# Altium schematic sheet SD_Card_Led_Monitor.SchDoc
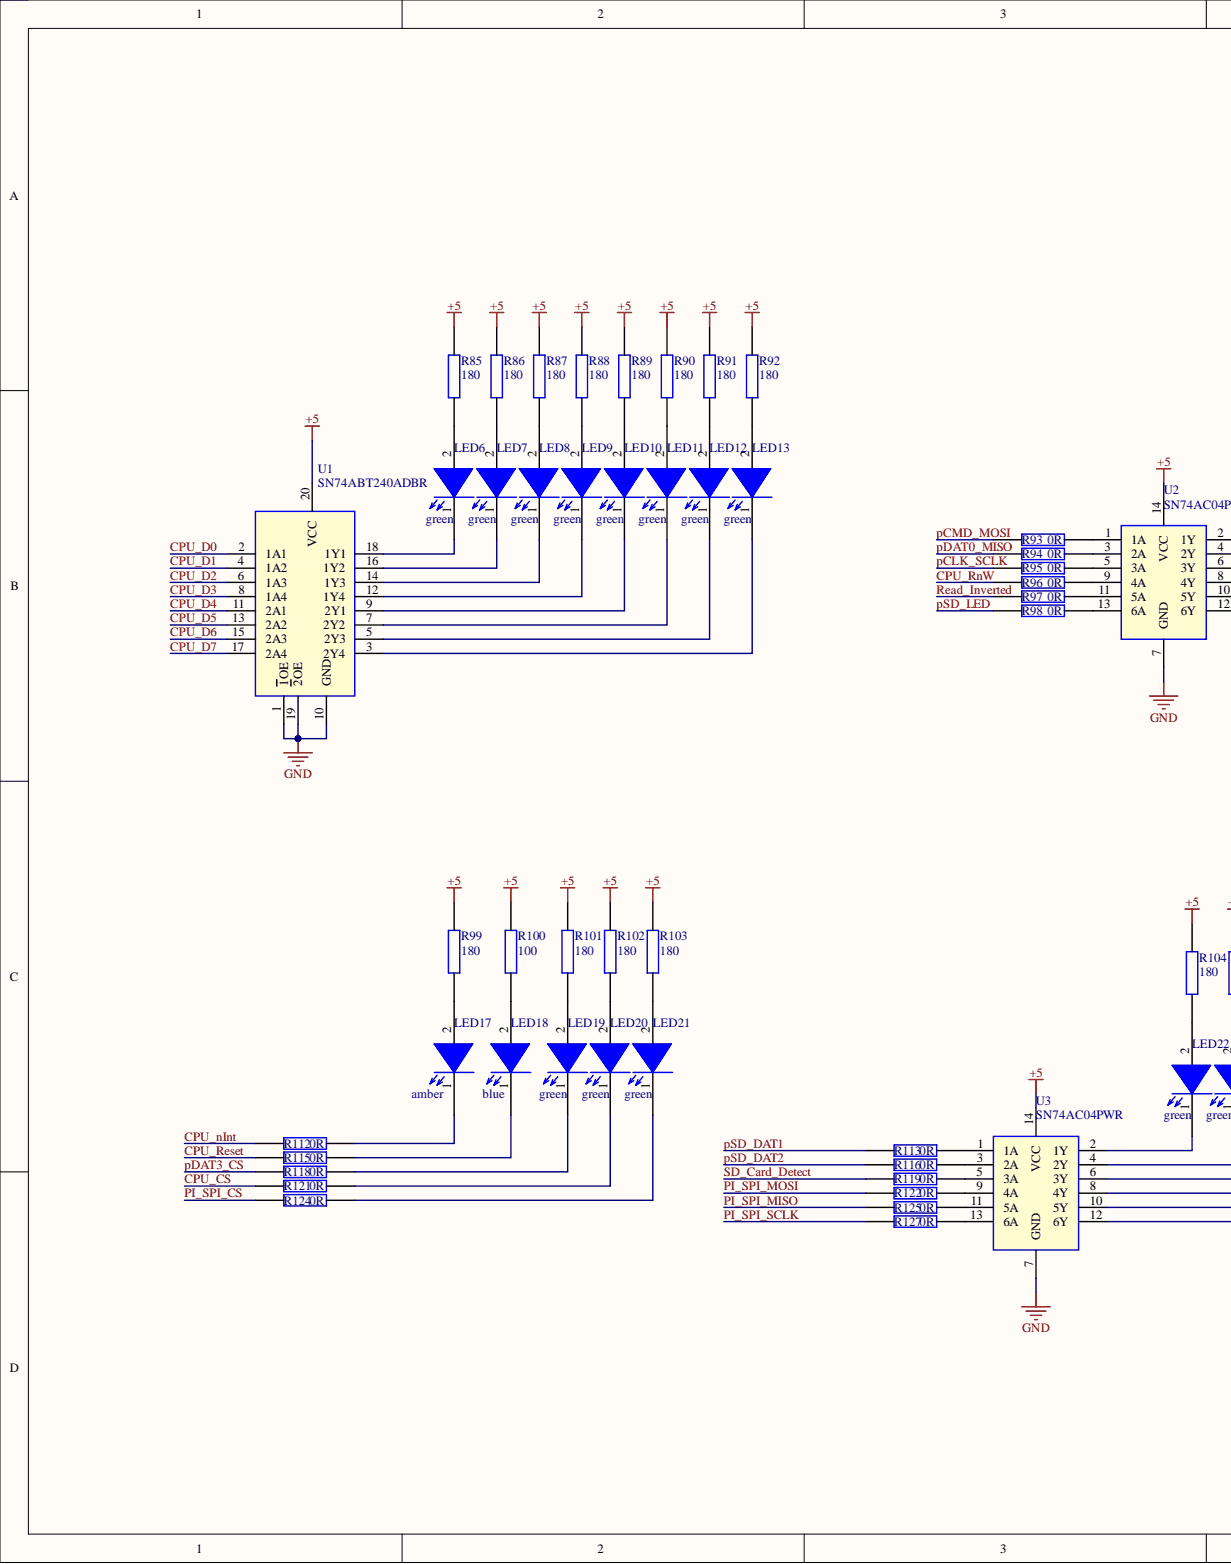
[diagram p0: SD_Card_Led_Monitor.SchDoc, left]
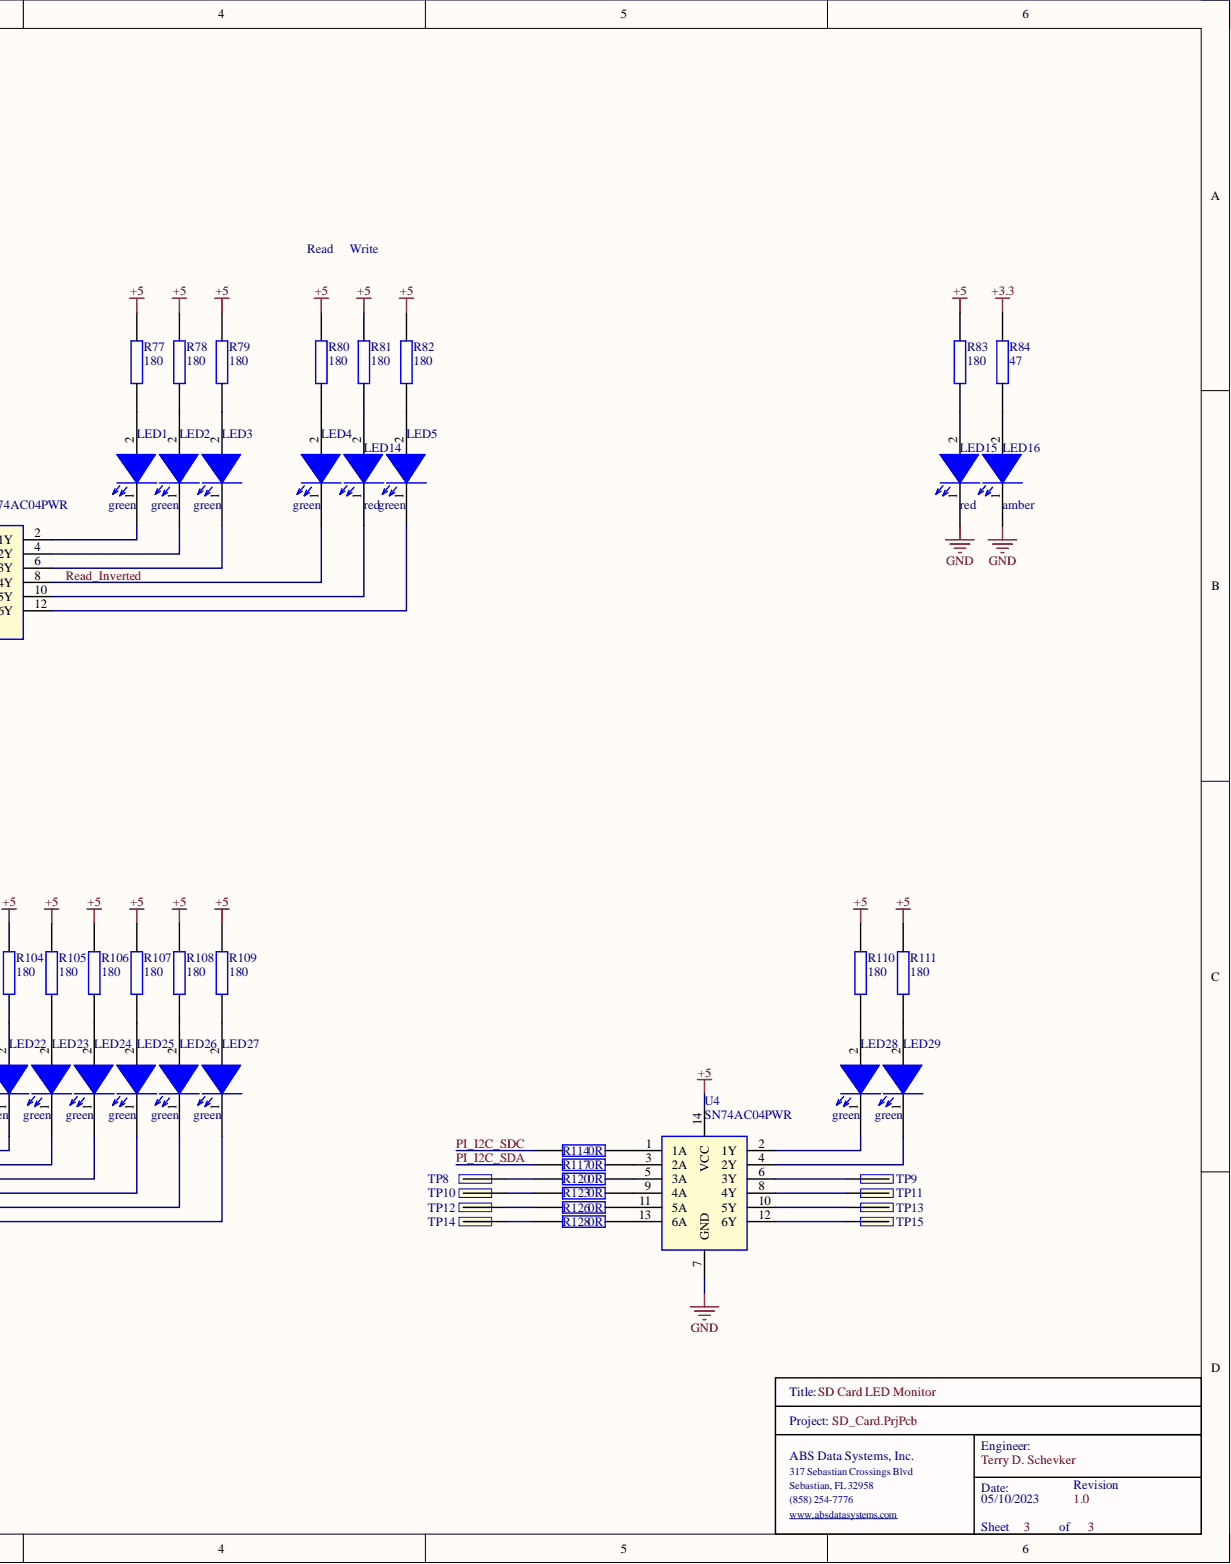
[diagram p1: SD_Card_Led_Monitor.SchDoc, right]

## Parts
LED1  green  [0603_Diode]
LED2  green  [0603_Diode]
LED3  green  [0603_Diode]
LED4  green  [0603_Diode]
LED5  green  [0603_Diode]
LED6  green  [0603_Diode]
LED7  green  [0603_Diode]
LED8  green  [0603_Diode]
LED9  green  [0603_Diode]
LED10  green  [0603_Diode]
LED11  green  [0603_Diode]
LED12  green  [0603_Diode]
LED13  green  [0603_Diode]
LED14  red  [0603_Diode]
LED15  red  [0603_Diode]
LED16  amber  [0603_Diode]
LED17  amber  [0603_Diode]
LED18  blue  [0603_Diode]
LED19  green  [0603_Diode]
LED20  green  [0603_Diode]
LED21  green  [0603_Diode]
LED22  green  [0603_Diode]
LED23  green  [0603_Diode]
LED24  green  [0603_Diode]
LED25  green  [0603_Diode]
LED26  green  [0603_Diode]
LED27  green  [0603_Diode]
LED28  green  [0603_Diode]
LED29  green  [0603_Diode]
R77  180  [0603]
R78  180  [0603]
R79  180  [0603]
R80  180  [0603]
R81  180  [0603]
R82  180  [0603]
R83  180  [0603]
R84  47  [0603]
R85  180  [0603]
R86  180  [0603]
R87  180  [0603]
R88  180  [0603]
R89  180  [0603]
R90  180  [0603]
R91  180  [0603]
R92  180  [0603]
R93  0R  [0603]
R94  0R  [0603]
R95  0R  [0603]
R96  0R  [0603]
R97  0R  [0603]
R98  0R  [0603]
R99  180  [0603]
R100  100  [0603]
R101  180  [0603]
R102  180  [0603]
R103  180  [0603]
R104  180  [0603]
R105  180  [0603]
R106  180  [0603]
R107  180  [0603]
R108  180  [0603]
R109  180  [0603]
R110  180  [0603]
R111  180  [0603]
R112  0R  [0603]  (value not drawn)
R113  0R  [0603]  (value not drawn)
R114  0R  [0603]  (value not drawn)
R115  0R  [0603]  (value not drawn)
R116  0R  [0603]  (value not drawn)
R117  0R  [0603]  (value not drawn)
R118  0R  [0603]  (value not drawn)
R119  0R  [0603]  (value not drawn)
R120  0R  [0603]  (value not drawn)
R121  0R  [0603]  (value not drawn)
R122  0R  [0603]  (value not drawn)
R123  0R  [0603]  (value not drawn)
R124  0R  [0603]  (value not drawn)
R125  0R  [0603]  (value not drawn)
R126  0R  [0603]  (value not drawn)
R127  0R  [0603]  (value not drawn)
R128  0R  [0603]  (value not drawn)
TP8  TP  [TP]
TP9  TP  [TP]
TP10  TP  [TP]
TP11  TP  [TP]
TP12  TP  [TP]
TP13  TP  [TP]
TP14  TP  [TP]
TP15  TP  [TP]
U1  SN74ABT240ADBR  [SOP65P780X200-20N]
U2  SN74AC04PWR  [SOP65P640X120-14N]
U3  SN74AC04PWR  [SOP65P640X120-14N]
U4  SN74AC04PWR  [SOP65P640X120-14N]

## Nets
+3.3: R84.1
+5: R77.2 R78.2 R79.2 R80.2 R81.2 R82.2 R83.2 R85.2 R86.2 R87.2 R88.2 R89.2 R90.2 R91.2 R92.2 R99.2 R100.1 R101.2 R102.2 R103.2 R104.2 R105.2 R106.2 R107.2 R108.2 R109.2 R110.2 R111.2 U1.20(VCC) U2.14(VCC) U3.14(VCC) U4.14(VCC)
GND: LED15.1 LED16.1 U1.1(1OE) U1.10(GND) U1.19(2OE) U2.7(GND) U3.7(GND) U4.7(GND)
CPU_CS: R121.1
CPU_D0: U1.2(1A1)
CPU_D1: U1.4(1A2)
CPU_D2: U1.6(1A3)
CPU_D3: U1.8(1A4)
CPU_D4: U1.11(2A1)
CPU_D5: U1.13(2A2)
CPU_D6: U1.15(2A3)
CPU_D7: U1.17(2A4)
CPU_nInt: R112.1
CPU_Reset: R115.1
CPU_RnW: R96.1
pCLK_SCLK: R95.1
pCMD_MOSI: R93.1
pDAT0_MISO: R94.1
pDAT3_CS: R118.1
PI_I2C_SDA: R117.1
PI_I2C_SDC: R114.1
PI_SPI_CS: R124.1
PI_SPI_MISO: R125.1
PI_SPI_MOSI: R122.1
PI_SPI_SCLK: R127.1
pSD_DAT1: R113.1
pSD_DAT2: R116.1
pSD_LED: R98.1
Read_Inverted: LED4.1 R97.1 U2.8(4Y)
SD_Card_Detect: R119.1
NetLED1_1: LED1.1 U2.2(1Y)
NetLED1_2: LED1.2 R77.1
NetLED2_1: LED2.1 U2.4(2Y)
NetLED2_2: LED2.2 R78.1
NetLED3_1: LED3.1 U2.6(3Y)
NetLED3_2: LED3.2 R79.1
NetLED4_2: LED4.2 R80.1
NetLED5_1: LED5.1 U2.12(6Y)
NetLED5_2: LED5.2 R82.1
NetLED6_1: LED6.1 U1.18(1Y1)
NetLED6_2: LED6.2 R85.1
NetLED7_1: LED7.1 U1.16(1Y2)
NetLED7_2: LED7.2 R86.1
NetLED8_1: LED8.1 U1.14(1Y3)
NetLED8_2: LED8.2 R87.1
NetLED9_1: LED9.1 U1.12(1Y4)
NetLED9_2: LED9.2 R88.1
NetLED10_1: LED10.1 U1.9(2Y1)
NetLED10_2: LED10.2 R89.1
NetLED11_1: LED11.1 U1.7(2Y2)
NetLED11_2: LED11.2 R90.1
NetLED12_1: LED12.1 U1.5(2Y3)
NetLED12_2: LED12.2 R91.1
NetLED13_1: LED13.1 U1.3(2Y4)
NetLED13_2: LED13.2 R92.1
NetLED14_1: LED14.1 U2.10(5Y)
NetLED14_2: LED14.2 R81.1
NetLED15_2: LED15.2 R83.1
NetLED16_2: LED16.2 R84.2
NetLED17_1: LED17.1 R112.2
NetLED17_2: LED17.2 R99.1
NetLED18_1: LED18.1 R115.2
NetLED18_2: LED18.2 R100.2
NetLED19_1: LED19.1 R118.2
NetLED19_2: LED19.2 R101.1
NetLED20_1: LED20.1 R121.2
NetLED20_2: LED20.2 R102.1
NetLED21_1: LED21.1 R124.2
NetLED21_2: LED21.2 R103.1
NetLED22_1: LED22.1 U3.2(1Y)
NetLED22_2: LED22.2 R104.1
NetLED23_1: LED23.1 U3.4(2Y)
NetLED23_2: LED23.2 R105.1
NetLED24_1: LED24.1 U3.6(3Y)
NetLED24_2: LED24.2 R106.1
NetLED25_1: LED25.1 U3.8(4Y)
NetLED25_2: LED25.2 R107.1
NetLED26_1: LED26.1 U3.10(5Y)
NetLED26_2: LED26.2 R108.1
NetLED27_1: LED27.1 U3.12(6Y)
NetLED27_2: LED27.2 R109.1
NetLED28_1: LED28.1 U4.2(1Y)
NetLED28_2: LED28.2 R110.1
NetLED29_1: LED29.1 U4.4(2Y)
NetLED29_2: LED29.2 R111.1
NetR93_2: R93.2 U2.1(1A)
NetR94_2: R94.2 U2.3(2A)
NetR95_2: R95.2 U2.5(3A)
NetR96_2: R96.2 U2.9(4A)
NetR97_2: R97.2 U2.11(5A)
NetR98_2: R98.2 U2.13(6A)
NetR113_2: R113.2 U3.1(1A)
NetR114_2: R114.2 U4.1(1A)
NetR116_2: R116.2 U3.3(2A)
NetR117_2: R117.2 U4.3(2A)
NetR119_2: R119.2 U3.5(3A)
NetR120_1: R120.1 TP8.1
NetR120_2: R120.2 U4.5(3A)
NetR122_2: R122.2 U3.9(4A)
NetR123_1: R123.1 TP10.1
NetR123_2: R123.2 U4.9(4A)
NetR125_2: R125.2 U3.11(5A)
NetR126_1: R126.1 TP12.1
NetR126_2: R126.2 U4.11(5A)
NetR127_2: R127.2 U3.13(6A)
NetR128_1: R128.1 TP14.1
NetR128_2: R128.2 U4.13(6A)
NetTP9_1: TP9.1 U4.6(3Y)
NetTP11_1: TP11.1 U4.8(4Y)
NetTP13_1: TP13.1 U4.10(5Y)
NetTP15_1: TP15.1 U4.12(6Y)

## Library data of the parts
LED1-LED13 LED19-LED29 = 150060GS75000: Wurth Elektronik 0603 green; Manufacturer: Wurth Electronics; Dominant Wavelength: 520nm; Forward Current: 30mA; Forward Voltage: 3.2V; Lens Style: Clear,Rectangular; Luminous Intensity: 430mcd; Test Current: 20mA; Viewing Angle: 140°; Case Code (Imperial): 0603; Case Code (Metric): 1608; Case/Package: 0603; Category: LEDs; Color: Green; Component Kind: Standard; ComponentLink1Description: https://datasheet.ciiva.com/29562/150060gs75000-29562868.pdf?src-supplier=Digi-Key; ComponentLink1URL: https://datasheet.ciiva.com/29562/150060gs75000-29562868.pdf?src-supplier=Digi-Key; ComponentLink2Description: https://datasheet.ciiva.com/29279/759427-da-01-en-chipled-0603-w-kl-gruen-150060gs75000-29279201.pdf?src-supplier=Conrad; ComponentLink2URL: https://datasheet.ciiva.com/29279/759427-da-01-en-chipled-0603-w-kl-gruen-150060gs75000-29279201.pdf?src-supplier=Conrad; ComponentLink3Description: https://datasheet.ciiva.com/29562/150060gs75000-29562869.pdf?src-supplier=Digi-Key; ComponentLink3URL: https://datasheet.ciiva.com/29562/150060gs75000-29562869.pdf?src-supplier=Digi-Key; ComponentLink4Description: https://datasheet.ciiva.com/29562/150060gs75000-29562870.pdf?src-supplier=Digi-Key; ComponentLink4URL: https://datasheet.ciiva.com/29562/150060gs75000-29562870.pdf?src-supplier=Digi-Key; Height: 0.7mm; Lead Free: Lead Free; Length: 1.6mm; Library Name: Misc.SchLib; Manufacturer Part Number: 150060GS75000; Max Operating Temperature: 85°C; Min Operating Temperature: -40°C; Mount: Surface Mount; Package Quantity: 4000; Packaging: Tape and Reel; Pricing 1: 1=0.14000, 50=0.13300, 100=0.11400, 500=0.09900 (USD); REACH SVHC: Unknown; RoHS: Yes; Stock 1: 71509; Supplier 1: Digi-Key; Wavelength: 520nm; Width: 0.8mm
LED14 LED15 = 150060RS75000: Wurth Elektronik 0603 red; Manufacturer: Wurth Electronics; Manufacturer Part Number: 150060GS75000; Color: Green; Dominant Wavelength: 520nm; Forward Current: 30mA; Forward Voltage: 3.2V; Lens Style: Clear,Rectangular; Luminous Intensity: 430mcd; Test Current: 20mA; Viewing Angle: 140°; Case Code (Imperial): 0603; Case Code (Metric): 1608; Case/Package: 0603; Category: LEDs; Component Kind: Standard; ComponentLink1Description: https://datasheet.ciiva.com/29562/150060gs75000-29562868.pdf?src-supplier=Digi-Key; ComponentLink1URL: https://datasheet.ciiva.com/29562/150060gs75000-29562868.pdf?src-supplier=Digi-Key; ComponentLink2Description: https://datasheet.ciiva.com/29279/759427-da-01-en-chipled-0603-w-kl-gruen-150060gs75000-29279201.pdf?src-supplier=Conrad; ComponentLink2URL: https://datasheet.ciiva.com/29279/759427-da-01-en-chipled-0603-w-kl-gruen-150060gs75000-29279201.pdf?src-supplier=Conrad; ComponentLink3Description: https://datasheet.ciiva.com/29562/150060gs75000-29562869.pdf?src-supplier=Digi-Key; ComponentLink3URL: https://datasheet.ciiva.com/29562/150060gs75000-29562869.pdf?src-supplier=Digi-Key; ComponentLink4Description: https://datasheet.ciiva.com/29562/150060gs75000-29562870.pdf?src-supplier=Digi-Key; ComponentLink4URL: https://datasheet.ciiva.com/29562/150060gs75000-29562870.pdf?src-supplier=Digi-Key; Height: 0.7mm; Lead Free: Lead Free; Length: 1.6mm; Library Name: Misc.SchLib; Max Operating Temperature: 85°C; Min Operating Temperature: -40°C; Mount: Surface Mount; Package Quantity: 4000; Packaging: Tape and Reel; Pricing 1: 1=0.14000, 50=0.13300, 100=0.11400, 500=0.09900 (USD); REACH SVHC: Unknown; RoHS: Yes; Stock 1: 71509; Supplier 1: Digi-Key; Wavelength: 520nm; Width: 0.8mm
LED16 LED17 = 150060AS75000: Wurth Elektronik 0603 amber; Manufacturer: Wurth Electronics; Manufacturer Part Number: 150060GS75000; Color: Green; Dominant Wavelength: 520nm; Forward Current: 30mA; Forward Voltage: 3.2V; Lens Style: Clear,Rectangular; Luminous Intensity: 430mcd; Test Current: 20mA; Viewing Angle: 140°; Case Code (Imperial): 0603; Case Code (Metric): 1608; Case/Package: 0603; Category: LEDs; Component Kind: Standard; ComponentLink1Description: https://datasheet.ciiva.com/29562/150060gs75000-29562868.pdf?src-supplier=Digi-Key; ComponentLink1URL: https://datasheet.ciiva.com/29562/150060gs75000-29562868.pdf?src-supplier=Digi-Key; ComponentLink2Description: https://datasheet.ciiva.com/29279/759427-da-01-en-chipled-0603-w-kl-gruen-150060gs75000-29279201.pdf?src-supplier=Conrad; ComponentLink2URL: https://datasheet.ciiva.com/29279/759427-da-01-en-chipled-0603-w-kl-gruen-150060gs75000-29279201.pdf?src-supplier=Conrad; ComponentLink3Description: https://datasheet.ciiva.com/29562/150060gs75000-29562869.pdf?src-supplier=Digi-Key; ComponentLink3URL: https://datasheet.ciiva.com/29562/150060gs75000-29562869.pdf?src-supplier=Digi-Key; ComponentLink4Description: https://datasheet.ciiva.com/29562/150060gs75000-29562870.pdf?src-supplier=Digi-Key; ComponentLink4URL: https://datasheet.ciiva.com/29562/150060gs75000-29562870.pdf?src-supplier=Digi-Key; Height: 0.7mm; Lead Free: Lead Free; Length: 1.6mm; Library Name: Misc.SchLib; Max Operating Temperature: 85°C; Min Operating Temperature: -40°C; Mount: Surface Mount; Package Quantity: 4000; Packaging: Tape and Reel; Pricing 1: 1=0.14000, 50=0.13300, 100=0.11400, 500=0.09900 (USD); REACH SVHC: Unknown; RoHS: Yes; Stock 1: 71509; Supplier 1: Digi-Key; Wavelength: 520nm; Width: 0.8mm
LED18 = 150060BS75000: Wurth Elektronik 0603 blue; Manufacturer: Wurth Electronics; Manufacturer Part Number: 150060GS75000; Color: Green; Dominant Wavelength: 520nm; Forward Current: 30mA; Forward Voltage: 3.2V; Lens Style: Clear,Rectangular; Luminous Intensity: 430mcd; Test Current: 20mA; Viewing Angle: 140°; Case Code (Imperial): 0603; Case Code (Metric): 1608; Case/Package: 0603; Category: LEDs; Component Kind: Standard; ComponentLink1Description: https://datasheet.ciiva.com/29562/150060gs75000-29562868.pdf?src-supplier=Digi-Key; ComponentLink1URL: https://datasheet.ciiva.com/29562/150060gs75000-29562868.pdf?src-supplier=Digi-Key; ComponentLink2Description: https://datasheet.ciiva.com/29279/759427-da-01-en-chipled-0603-w-kl-gruen-150060gs75000-29279201.pdf?src-supplier=Conrad; ComponentLink2URL: https://datasheet.ciiva.com/29279/759427-da-01-en-chipled-0603-w-kl-gruen-150060gs75000-29279201.pdf?src-supplier=Conrad; ComponentLink3Description: https://datasheet.ciiva.com/29562/150060gs75000-29562869.pdf?src-supplier=Digi-Key; ComponentLink3URL: https://datasheet.ciiva.com/29562/150060gs75000-29562869.pdf?src-supplier=Digi-Key; ComponentLink4Description: https://datasheet.ciiva.com/29562/150060gs75000-29562870.pdf?src-supplier=Digi-Key; ComponentLink4URL: https://datasheet.ciiva.com/29562/150060gs75000-29562870.pdf?src-supplier=Digi-Key; Height: 0.7mm; Lead Free: Lead Free; Length: 1.6mm; Library Name: Misc.SchLib; Max Operating Temperature: 85°C; Min Operating Temperature: -40°C; Mount: Surface Mount; Package Quantity: 4000; Packaging: Tape and Reel; Pricing 1: 1=0.14000, 50=0.13300, 100=0.11400, 500=0.09900 (USD); REACH SVHC: Unknown; RoHS: Yes; Stock 1: 71509; Supplier 1: Digi-Key; Wavelength: 520nm; Width: 0.8mm
R77-R83 R85-R92 R99 R101-R111 = RC0603FR-13180RL: YAGEO 0603 180 ohm; Manufacturer_Name: KEMET; Manufacturer_Part_Number: RC0603JR-070RL; Geometry.Height: 0.55mm; Component Kind: Standard; Datasheet Link: https://datasheet.datasheetarchive.com/originals/distributors/Datasheets_SAMA/902f9e387b938f871d31120f5fc1d65e.pdf; Library Name: Resistors.SchLib; Mouser Part Number: 603-RC0603JR-070RL; Mouser Price/Stock: https://www.mouser.co.uk/ProductDetail/YAGEO/RC0603JR-070RL?qs=2cAdsCoAWRGWyPcuXgGiXQ%3D%3D
R84 = RC0603FR-0747KL: YAGEO 0603 47; Manufacturer_Name: KEMET; Manufacturer_Part_Number: RC0603JR-070RL; Geometry.Height: 0.55mm; Component Kind: Standard; Datasheet Link: https://datasheet.datasheetarchive.com/originals/distributors/Datasheets_SAMA/902f9e387b938f871d31120f5fc1d65e.pdf; Library Name: Resistors.SchLib; Mouser Part Number: 603-RC0603JR-070RL; Mouser Price/Stock: https://www.mouser.co.uk/ProductDetail/YAGEO/RC0603JR-070RL?qs=2cAdsCoAWRGWyPcuXgGiXQ%3D%3D
R93-R98 R112-R128 = RC0603JR-070RL: YAGEO 0603 0 ohm; Manufacturer_Name: KEMET; Geometry.Height: 0.55mm; Component Kind: Standard; Datasheet Link: https://datasheet.datasheetarchive.com/originals/distributors/Datasheets_SAMA/902f9e387b938f871d31120f5fc1d65e.pdf; Library Name: Resistors.SchLib; Manufacturer_Part_Number: RC0603JR-070RL; Mouser Part Number: 603-RC0603JR-070RL; Mouser Price/Stock: https://www.mouser.co.uk/ProductDetail/YAGEO/RC0603JR-070RL?qs=2cAdsCoAWRGWyPcuXgGiXQ%3D%3D
R100 = RC0603FR-10100RL: YAGEO 0603 100 ohm; Manufacturer_Name: KEMET; Manufacturer_Part_Number: RC0603JR-070RL; Geometry.Height: 0.55mm; Component Kind: Standard; Datasheet Link: https://datasheet.datasheetarchive.com/originals/distributors/Datasheets_SAMA/902f9e387b938f871d31120f5fc1d65e.pdf; Library Name: Resistors.SchLib; Mouser Part Number: 603-RC0603JR-070RL; Mouser Price/Stock: https://www.mouser.co.uk/ProductDetail/YAGEO/RC0603JR-070RL?qs=2cAdsCoAWRGWyPcuXgGiXQ%3D%3D
TP8-TP15 = TP: Manufacturer: Samtec; Manufacturer Part Number: TST-105-01-G-D; Connector Type: Male Pin; Gender: Male; Number of Contacts: 16; Pitch: 2.54mm; Row Spacing: 0.1inch; Category: Headers and Wire Housings; Color: Black; Component Kind: Standard; ComponentLink1Description: https://datasheet.ciiva.com/739/tst-mkt-739845.pdf?src-supplier=Digi-Key; ComponentLink1URL: https://datasheet.ciiva.com/739/tst-mkt-739845.pdf?src-supplier=Digi-Key; ComponentLink2Description: https://datasheet.ciiva.com/10018/htst-10018510.pdf?src-supplier=Avnet; ComponentLink2URL: https://datasheet.ciiva.com/10018/htst-10018510.pdf?src-supplier=Avnet; Contact Material: Bronze; Contact Mating Length: 0.25inch; Contact Plating: Gold; Depth: 9.27mm; Housing Color: Black; Housing Material: Polyester; Length: 27.94mm; Library Name: Misc.SchLib; Max Operating Temperature: 125°C; Min Operating Temperature: -55°C; Mount: Through Hole; Number of Positions: 16; Number of Rows: 2; Orientation: Straight; Packaging: Rail/Tube; Pricing 1: 1=2.85000, 10=2.73600, 100=2.28000, 500=1.93800, 1000=1.65300, 5000=1.48200 (USD); REACH SVHC: No SVHC; RoHS: Yes; Stock 1: 227; Supplier 1: Digi-Key; Termination: Solder
U1 = SN74ABT240ADBR: Octal Buffers/Drivers With 3-State Outputs; Manufacturer_Name: Texas Instruments; Geometry.Height: 2mm; Component Kind: Standard; Datasheet Link: http://www.ti.com/lit/gpn/sn74abt240a; Library Name: Logic.SchLib; Manufacturer_Part_Number: SN74ABT240ADBR; Mouser Part Number: 595-SN74ABT240ADBR; Mouser Price/Stock: https://www.mouser.co.uk/ProductDetail/Texas-Instruments/SN74ABT240ADBR?qs=JHHQeKcAU3Dt82k%252BVyiYdw%3D%3D
U2-U4 = SN74AC04PWR: Hex Inverters; Manufacturer_Name: Texas Instruments; Geometry.Height: 1.2mm; Component Kind: Standard; Datasheet Link: http://www.ti.com/lit/gpn/sn74ac04; Library Name: Logic.SchLib; Manufacturer_Part_Number: SN74AC04PWR; Mouser Part Number: 595-SN74AC04PWR; Mouser Price/Stock: https://www.mouser.co.uk/ProductDetail/Texas-Instruments/SN74AC04PWR?qs=RZS%252BpEsUn7pRvPNqsga4SA%3D%3D
